# Altium schematic sheet BMS_project.SchDoc(BMS_project)
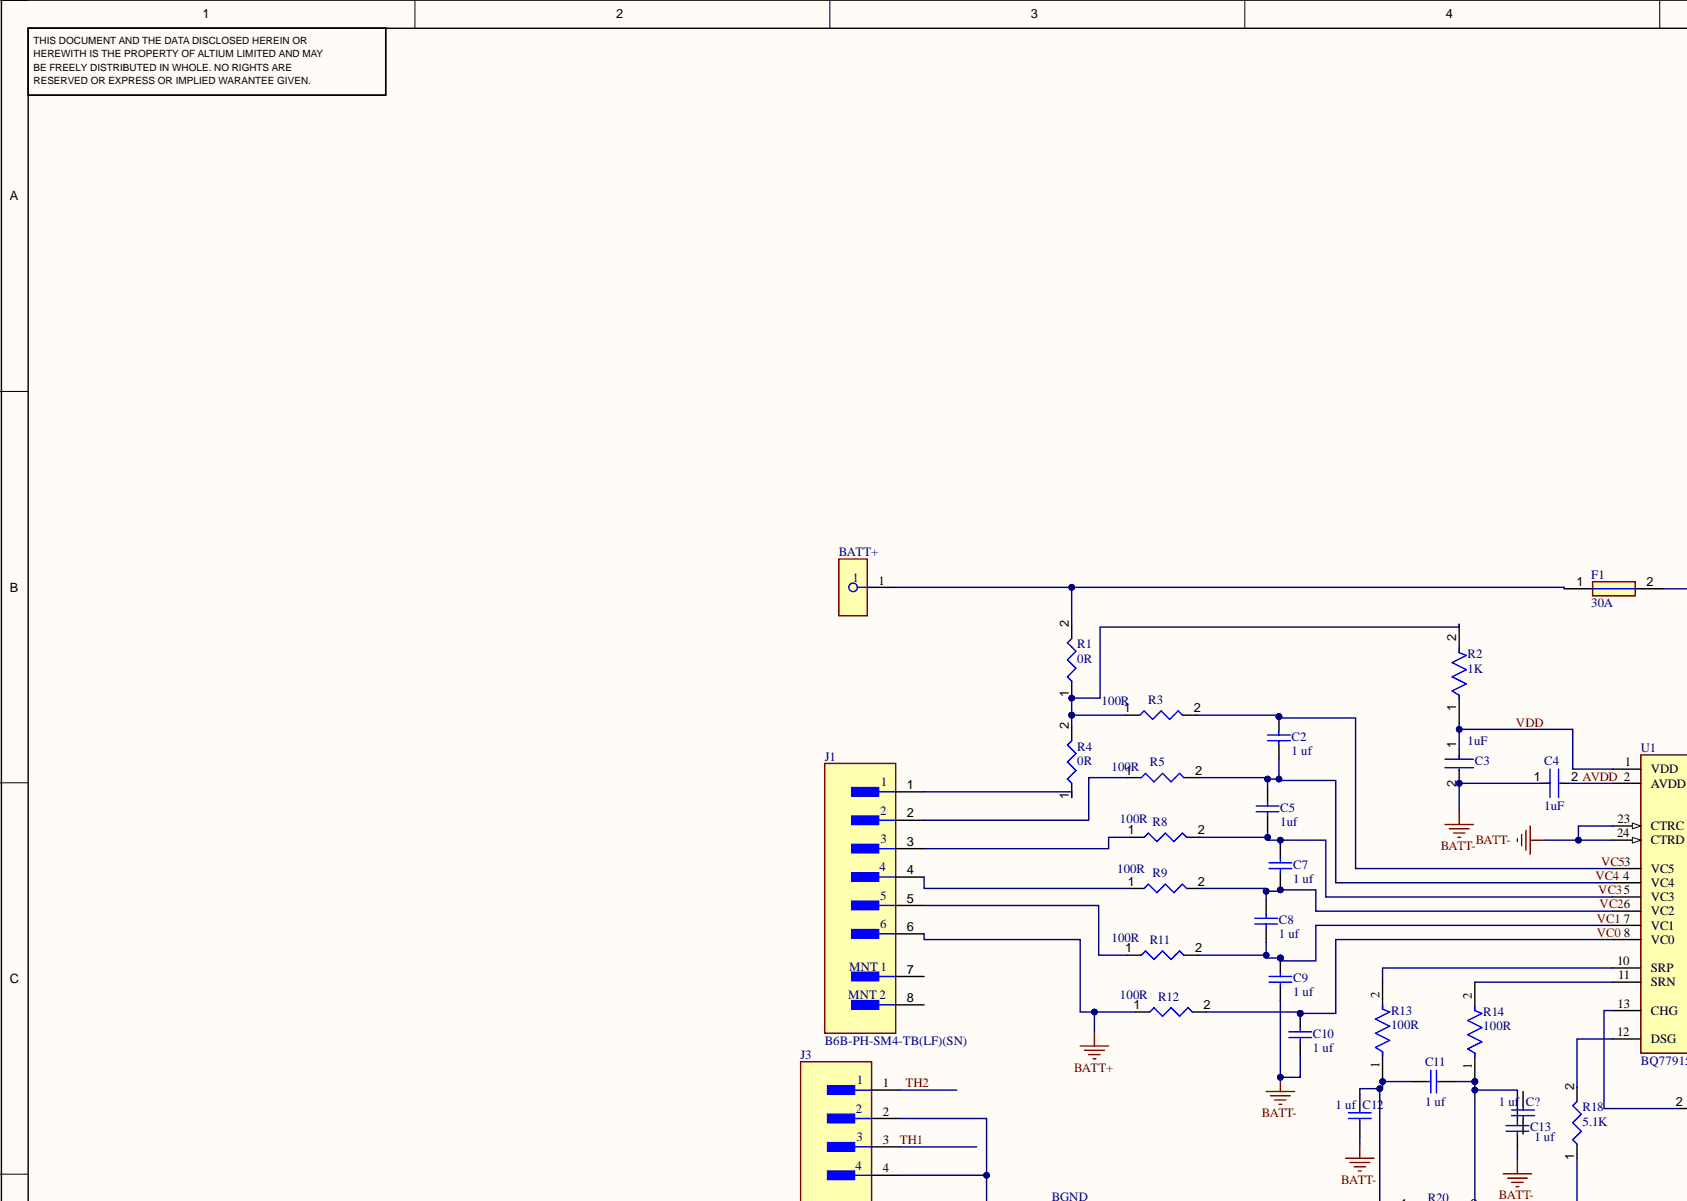
[diagram p0: BMS_project.SchDoc, top left]
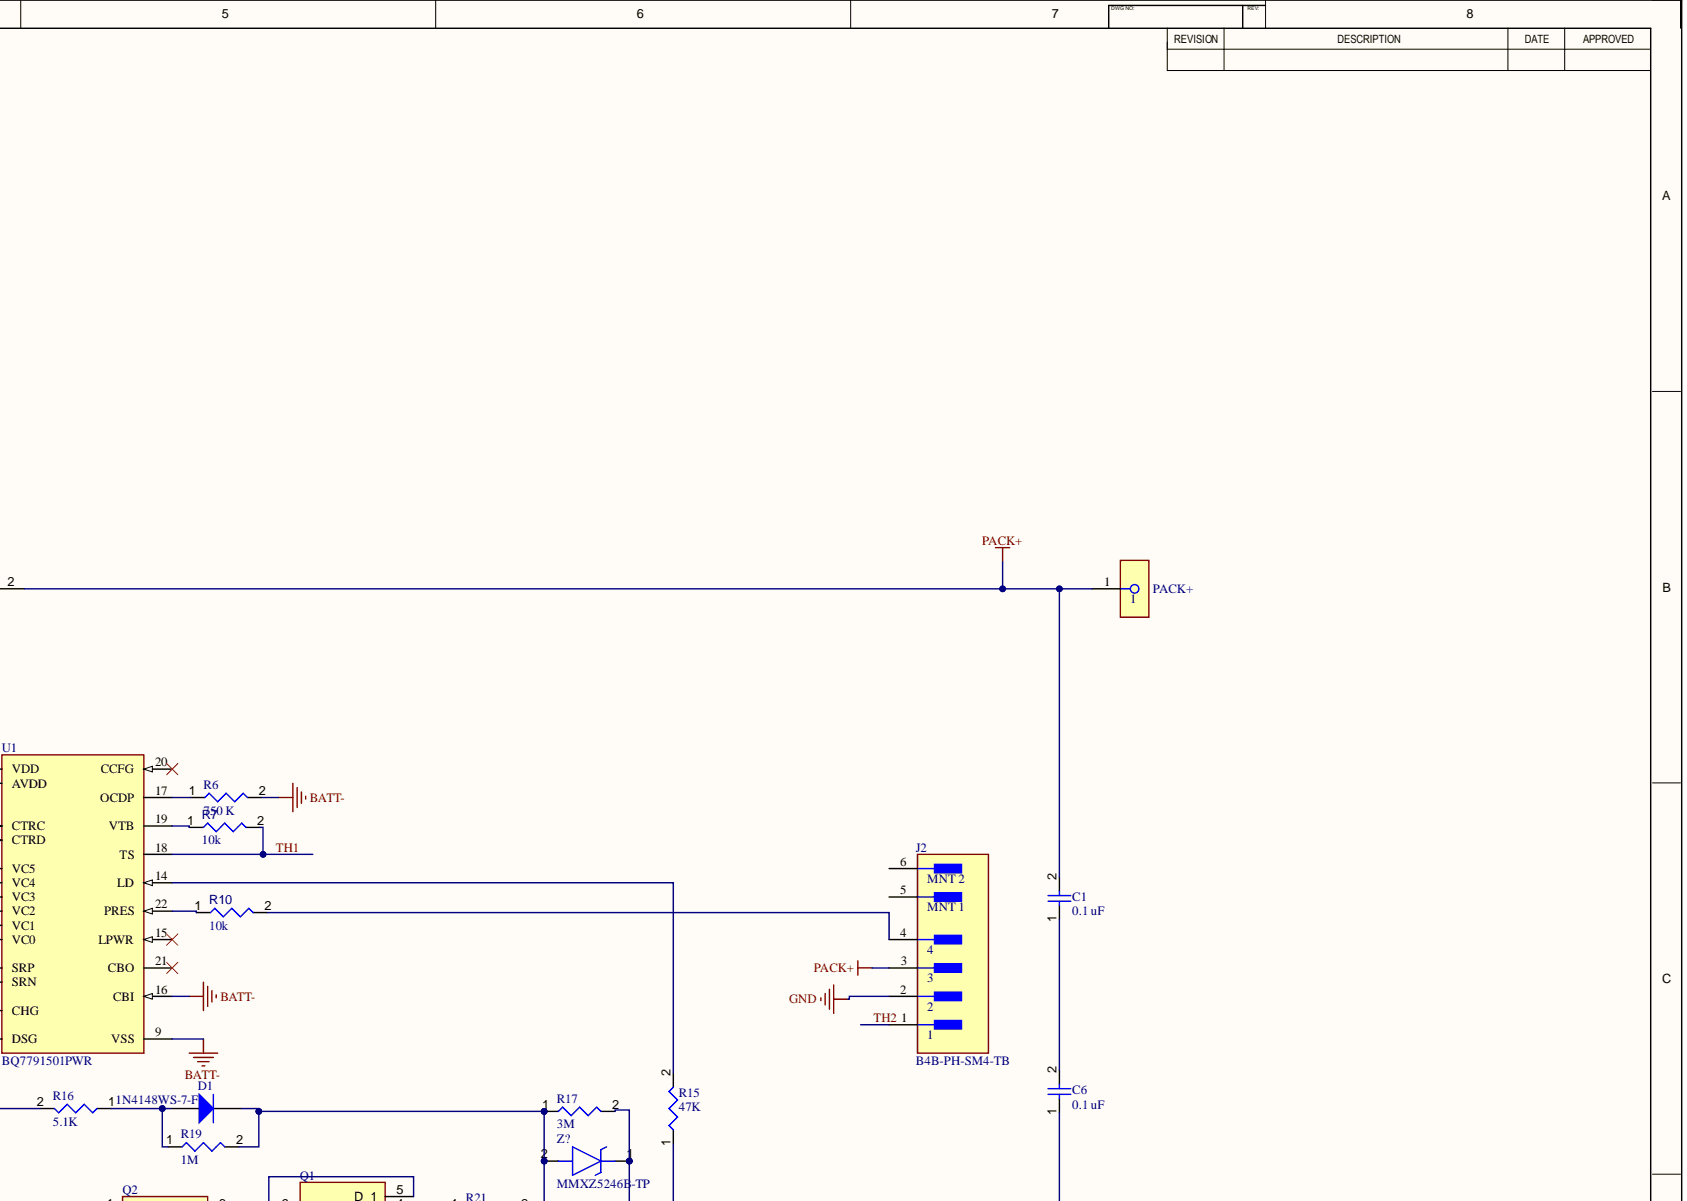
[diagram p1: BMS_project.SchDoc, top right]
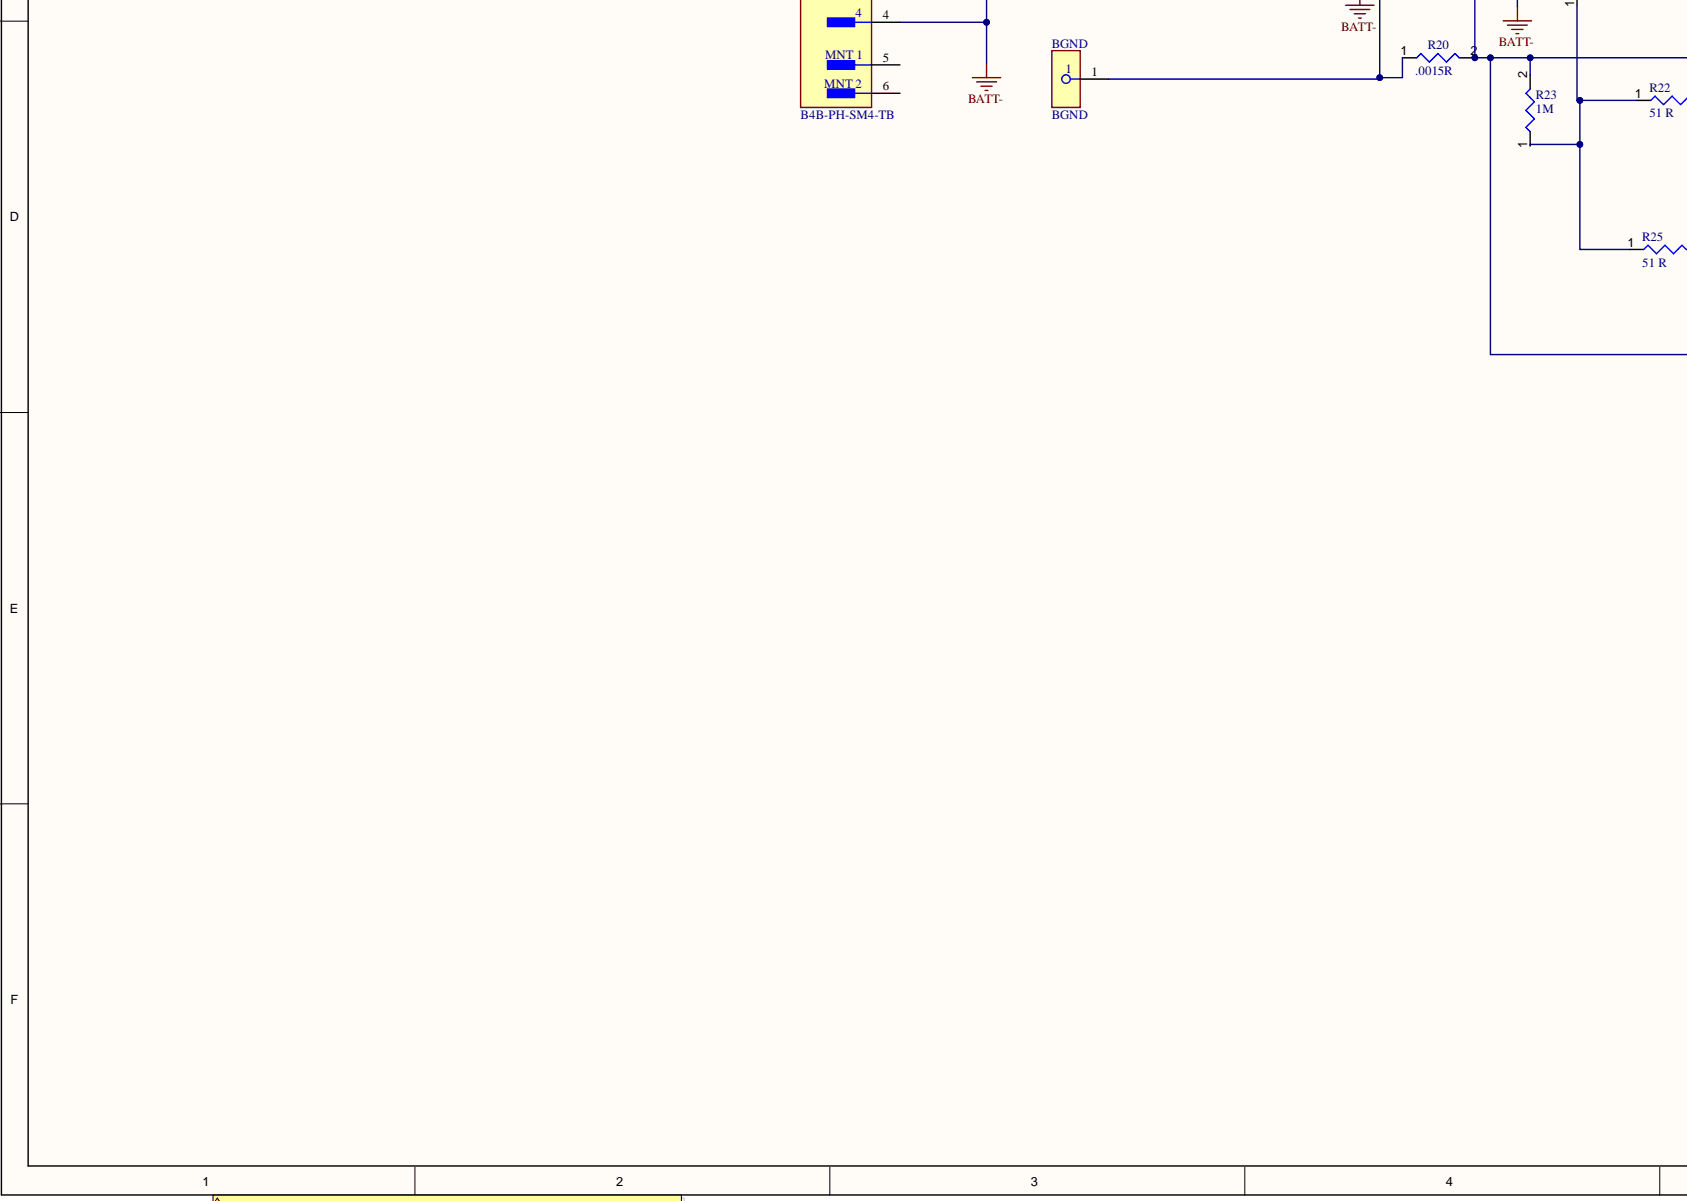
[diagram p2: BMS_project.SchDoc, bottom left]
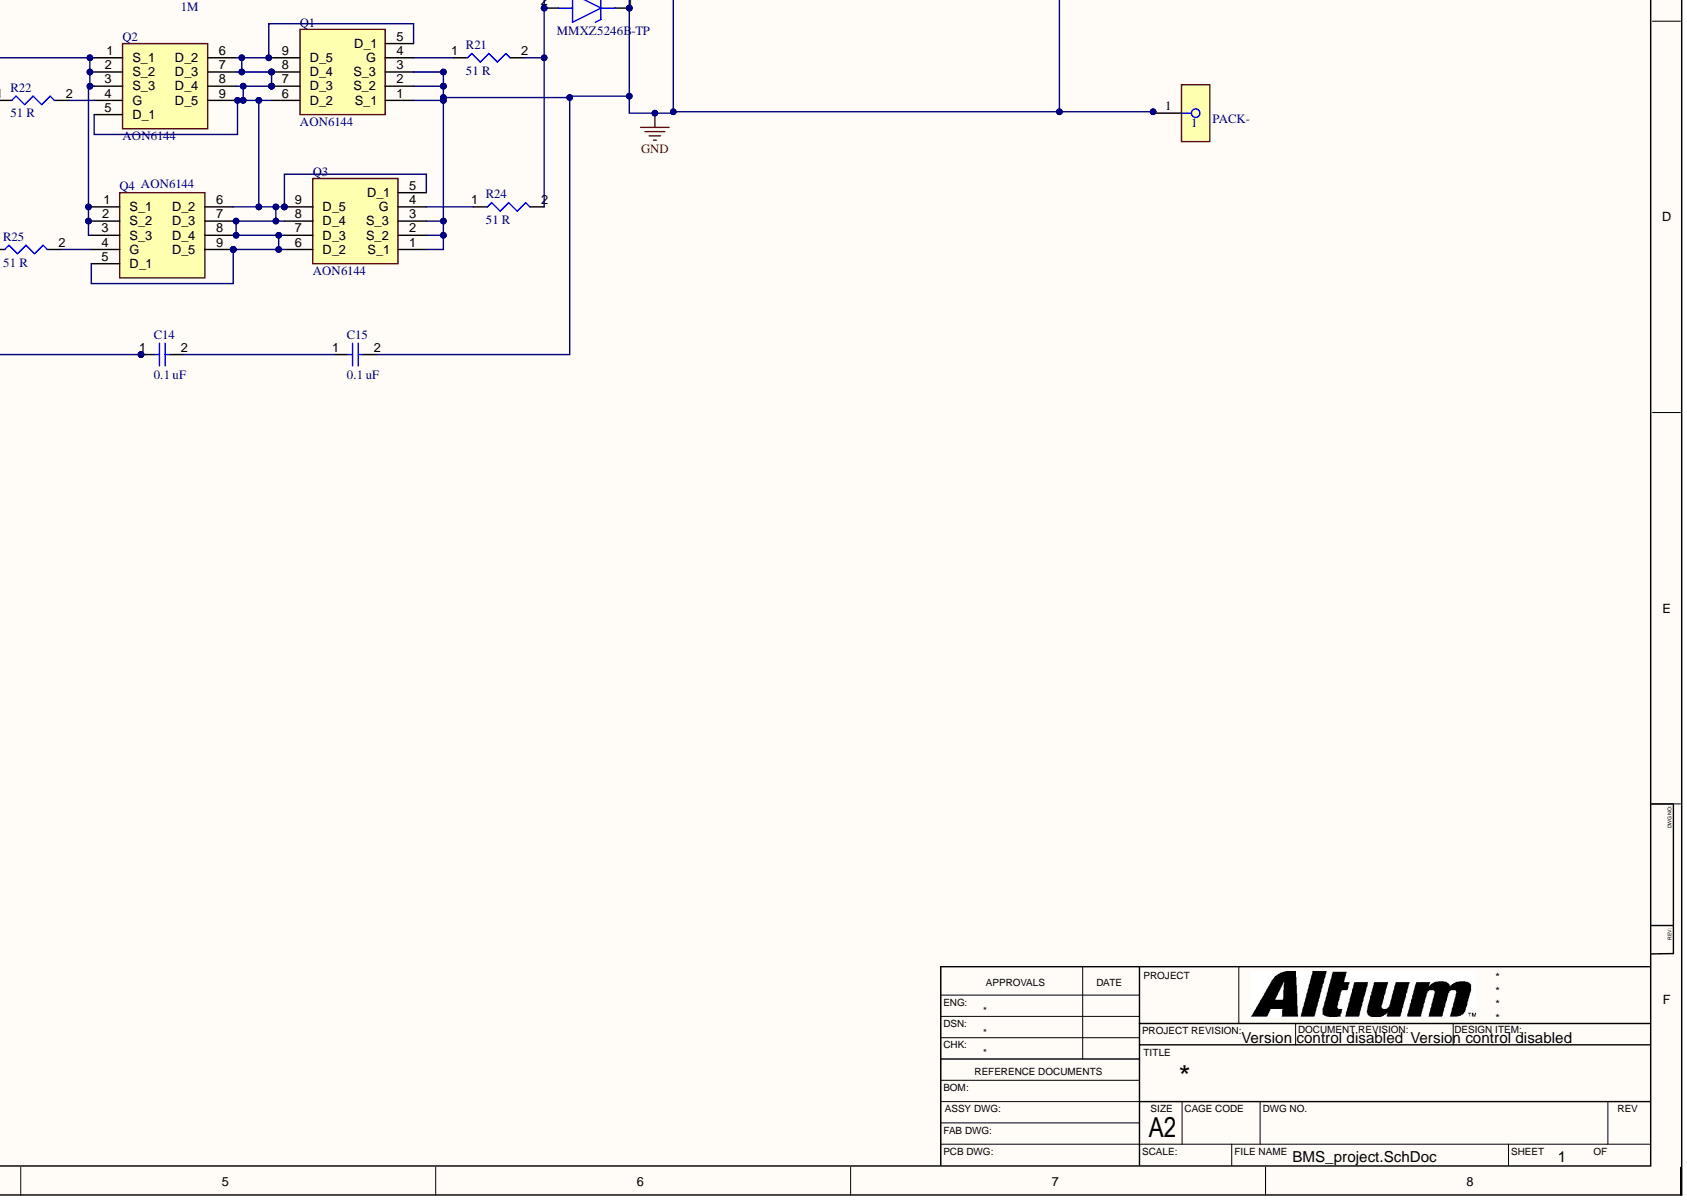
[diagram p3: BMS_project.SchDoc, bottom right]

## Parts
BATT+  BATT+
BGND  BGND
C1  0.1 uF
C2  1 uf
C3  1uF
C4  1uF
C5  1uf
C6  0.1 uF
C7  1 uf
C8  1 uf
C9  1 uf
C10  1 uf
C11  1 uf
C12  1 uf
C13  1 uf
C14  0.1 uF
C15  0.1 uF
C?  1 uf
D1  1N4148WS-7-F
F1  30A
J1  B6B-PH-SM4-TB(LF)(SN)
J2  B4B-PH-SM4-TB
J3  B4B-PH-SM4-TB
PACK+  (no value)
PACK-  LAMA2/0-14-QY  (value not drawn)
Q1  AON6144
Q2  AON6144
Q3  AON6144
Q4  AON6144
R1  0R
R2  1K
R3  100R
R4  0R
R5  100R
R6  750 K
R7  value 10k  (hidden comment: Resistor 10k +/-1% 0603 100 mW)
R8  100R
R9  100R
R10  value 10k  (hidden comment: Resistor 10k +/-1% 0603 100 mW)
R11  100R
R12  100R
R13  100R
R14  100R
R15  47K
R16  5.1K
R17  3M
R18  5.1K
R19  1M
R20  .0015R
R21  51 R
R22  51 R
R23  1M
R24  51 R
R25  51 R
U1  BQ7791501PWR
Z?  MMXZ5246B-TP

## Nets
BATT+: J1.6 R12.1
BATT-: C3.2 C4.1 C9.1 C10.1 C12.1 C13.1 J3.2 J3.4 R6.2 U1.9(VSS) U1.16(CBI) U1.23(CTRC) U1.24(CTRD)
GND: C6.1 C15.2 J2.2 PACK-.1 Q1.1(S_1) Q1.2(S_2) Q1.3(S_3) Q3.1(S_1) Q3.2(S_2) Q3.3(S_3) R15.1 R17.2 Z?.1
PACK+: C1.2 F1.2 J2.3 PACK+.1
AVDD: C4.2 U1.2(AVDD)
TH1: J3.3 R7.2 U1.18(TS)
TH2: J2.1 J3.1
VC0: C10.2 R12.2 U1.8(VC0)
VC1: C8.1 C9.2 R11.2 U1.7(VC1)
VC2: C7.1 C8.2 R9.2 U1.6(VC2)
VC3: C5.1 C7.2 R8.2 U1.5(VC3)
VC4: C2.1 C5.2 R5.2 U1.4(VC4)
VC5: C2.2 R3.2 U1.3(VC5)
VDD: C3.1 R2.1 U1.1(VDD)
NetBATT+_1: BATT+.1 F1.1 R1.2
NetBGND_1: BGND.1 C11.2 C12.2 R13.1 R20.1
NetC1_1: C1.1 C6.2
NetC11_1: C11.1 C13.2 C14.1 Q2.1(S_1) Q2.2(S_2) Q2.3(S_3) Q4.1(S_1) Q4.2(S_2) Q4.3(S_3) R14.1 R20.2 R23.2
NetC14_2: C14.2 C15.1
NetD1_1: D1.1 R16.1 R19.1
NetD1_2: D1.2 R17.1 R19.2 R21.2 R24.2 Z?.2
NetJ1_1: J1.1 R4.1
NetJ1_2: J1.2 R5.1
NetJ1_3: J1.3 R8.1
NetJ1_4: J1.4 R9.1
NetJ1_5: J1.5 R11.1
NetJ2_4: J2.4 R10.2
NetQ1_4: Q1.4(G) R21.1
NetQ1_5: Q1.5(D_1) Q1.6(D_2) Q1.7(D_3) Q1.8(D_4) Q1.9(D_5) Q2.5(D_1) Q2.6(D_2) Q2.7(D_3) Q2.8(D_4) Q2.9(D_5) Q3.5(D_1) Q3.6(D_2) Q3.7(D_3) Q3.8(D_4) Q3.9(D_5) Q4.5(D_1) Q4.6(D_2) Q4.7(D_3) Q4.8(D_4) Q4.9(D_5)
NetQ2_4: Q2.4(G) R22.2
NetQ3_4: Q3.4(G) R24.1
NetQ4_4: Q4.4(G) R25.2
NetR1_1: R1.1 R2.2 R3.1 R4.2
NetR6_1: R6.1 U1.17(OCDP)
NetR7_1: R7.1 U1.19(VTB)
NetR10_1: R10.1 U1.22(PRES)
NetR13_2: R13.2 U1.10(SRP)
NetR14_2: R14.2 U1.11(SRN)
NetR15_2: R15.2 U1.14(LD)
NetR16_2: R16.2 U1.13(CHG)
NetR18_1: R18.1 R22.1 R23.1 R25.1
NetR18_2: R18.2 U1.12(DSG)

Not connected: C? 1 2; J1 7 8; J2 5 6; J3 5 6; U1 15(LPWR) 20(CCFG) 21(CBO)

## Library data of the parts
BATT+ BGND PACK+ PACK- = CMP-00289-00092609-1: LAMA2/0-14-QY LAMA2/0-14-QY Aluminum Mechanical Lug, 1 Hole, 1/4", Sngle Barrel, 600V, 2/0-14AWG, 25Pcs; NexarPartId: 92609; Body Material: Aluminium; Body Plating: Tin; Gender: Female; Insulation: Non-Insulated; Number of Contacts: 1; Tab Thickness: 4.826mm; Wire Gauge (Max): 2AWG; Wire Gauge (Min): 14AWG; Wire/Cable Gauge: 0AWG; Case/Package: Aluminum; Component Kind: Standard; Contact Material: Aluminium; Contact Plating: Tin; Depth: 15.7mm; Length: 37.3mm; Library Name: Altium Content Vault; Material: Aluminium; Max Operating Temperature: 90°C; Mount: Screw; Orientation: Straight; Radiation Hardening: No; REACH SVHC: No SVHC; RoHS: Compliant; Termination: Screw; Type: Mechanical; Voltage Rating: 600V
C1 C6 C14 C15 = C0805C104K1RACTU: Ceramic Capacitor, Multilayer, Ceramic, 100V, 10% +Tol, 10% -Tol, X7R, 15% TC, 0.1uF, Surface Mount, 0805; Manufacturer: KEMET; Multilayer: Yes; Value: 100nF; Capacitor Type: Ceramic; Case/Package: 0805; Category: Ceramic Capacitors; Component Kind: Standard; ComponentLink1Description: https://datasheet.ciiva.com:443/pdfs/VipMasterIC/IC/KEME/KEME-S-A0008991654/KEME-S-A0008991654-1.pdf?src-supplier=IHS+Markit; ComponentLink1URL: https://datasheet.ciiva.com:443/pdfs/VipMasterIC/IC/KEME/KEME-S-A0008991654/KEME-S-A0008991654-1.pdf?src-supplier=IHS+Markit; ComponentLink2Description: https://datasheet.ciiva.com/7842/1776102-7842535.pdf?src-supplier=Element14; ComponentLink2URL: https://datasheet.ciiva.com/7842/1776102-7842535.pdf?src-supplier=Element14; ComponentLink3Description: https://datasheet.ciiva.com/18219/getdatasheetpartid-295573-18219253.pdf?src-supplier=Verical; ComponentLink3URL: https://datasheet.ciiva.com/18219/getdatasheetpartid-295573-18219253.pdf?src-supplier=Verical; Dielectric Material: Ceramic; Height: 1mm; JESD-609 Code: e3; Length: 2mm; Library Name: BMS_project.SchLib; Manufacturer Part Number: C0805C104K1RACTU; Max Operating Temperature: 125°C; Min Operating Temperature: -55°C; Mounting Technology: Surface Mount; Package Shape: Rectangular; Package Style: SMTMeter; Packaging: Tape and Reel; Pins: 2; Pricing: 1=0.18, 10=0.123, 50=0.123, 100=0.072, 1000=0.046, 10000=0.036 (USD); Rated DC Voltage (URdc): 100V; Reach SVHC Compliant: Yes; RoHS: Yes; RoHS Compliant: Yes; Stock: 206983; Supplier: Mouser; Supplier Part Number: 80-C0805C104K1R; Temperature Characteristics Code: X7R; Terminal Finish: Nickel; Termination: Wraparound; Tolerance  (fill in as +-): 10%; Tolerance (fill in as +-): 10%; Width: 1.25mm
C2 C5 C7-C13 C? = CMP-2007-03236-1: Manufacturer: Kemet; Part Number: C0805C105K5RACTU; Component Kind: Standard; Library Name: Altium Content Vault
C3 C4 = CMP-1036-04428-2: CAP CER 0.1UF 100V X7R 0805; Manufacturer: KEMET; Manufacturer Part Number: C0805C104K1RACTU; Capacitance: 0.10 μF; Material: Ceramic; Temperature Coefficient: 30ppm/°C; Tolerance: 0.1; Value: 0.10 μF; Automotive: No; Category: Cap; Component Kind: Standard; ComponentLink2Description: Datasheet; ComponentLink2URL: https://content.kemet.com/datasheets/KEM_C1003_C0G_SMD.pdf; Device Class L1: Passive Components; Device Class L2: Capacitors; Device Class L3: Ceramic Capacitors; Digikey Description: CAP CER 0.1UF 100V X7R 0805; Height: 0.88mm; Ipc Land Pattern Name: CAPC200125X78; Lead Free: Yes; Library Name: Altium Content Vault; Mouser Description: Capacitor 100 nF 100 VDC 0805; Octopart: https://octopart.com/c0805c104k1ractu-kemet-103953; Package: C0805C-DN; RoHS: TRUE; Supplier: Digikey; Supplier Part Number: 800-3746-1-ND; Temperature: -55°C to +125°C; Temperature Characteristic: X7R; Temperature Range High: +125°C; Temperature Range Low: -55°C; Voltage Rating: 100 V
D1 = CMP-004-00002-2: Fast Switching Diode, 75 V, 0.3 A, -65 to 150 degC, 2-Pin SOD323, RoHS, Tape and Reel; Manufacturer: Diodes Inc; Elements: 1; Forward Current: 300mA; Forward Voltage: 1.25V; Max Surge Current: 2A; Peak Reverse Current: 1uA; Recovery Time: 4ns; Case/Package: SOD; Component Kind: Standard; Datasheet URL: http://www.diodes.com/datasheets/ds30097.pdf; Datasheet Version: Rev. 25-2, 03/2016; Manufacturer URL: http://www.diodes.com/; Max Operating Temperature: 150°C; Min Operating Temperature: -65°C; Mounting Technology: SM; Package Description: 2-Pin Small Outline Diode, Body 1.7 x 1.3 mm; Package Quantity: 3000; Package Reference: SOD323-2; Packaging: TapeandReel; Pins: 2; RoHS Compliant: True; Library Name: Vijaya Bhaskar reddy Ambati
F1 = SF-3812F3000T-2: Fuse; Manufacturer_Name: Bourns; Component Kind: Standard; Datasheet Link: https://www.bourns.com/docs/product-datasheets/SF-3812F-T.pdf; Height: 3.3mm; Manufacturer_Part_Number: SF-3812F3000T-2; Mouser Part Number: 652-SF-3812F3000T-2; Mouser Price/Stock: https://www.mouser.co.uk/ProductDetail/Bourns/SF-3812F3000T-2?qs=vLWxofP3U2yO4nPWBdNZtQ%3D%3D
J1 = CMP-17439-000051-1: CONN HEADER SMD 6POS 2MM; Contact Resistance: 10mR; Current Rating: 2A; Gender: Male; Insulation Resistance: 1GR; Mated Stacking Heights: 8.6mm; Number of Contacts: 6; Voltage Rating: 100V; Color: Natural; Component Kind: Standard; Connector Type: Header; Contact Finish - Mating: TIN; Contact Material: Copper Alloy; Contact Mating Length: 0.13inch; Contact Plating: Tin; Contact Shape: Square; Contact Type: Male Pin; Fastening Type: Detent; Flammability Rating: UL94 V-0; Height: 9.4mm; Housing Color: Natural; Housing Material: Nylon; Insulation Color: Natural; Insulation Height: 0.26inch; Insulation Material: Nylon; Lead Free: Lead Free; Lead Pitch: 2mm; Length: 13.9mm; Library Name: Altium Content Vault; Max Operating Temperature: 85°C; Min Operating Temperature: -25°C; Mount: Surface Mount; Number of Poles: 6; Number of Positions: 6; Number of Rows: 1; Orientation: Straight; Packaging: Tape and Reel; Pitch: 2mm; REACH SVHC: No SVHC; Series: PH; Termination: Solder; Voltage Rating (AC): 100V; Width: 5.5mm; Working Voltage: 100V
J2 J3 = CMP-17439-000131-1: CONN HEADER SMD 4POS 2MM; Component Kind: Standard; Library Name: Altium Content Vault; Orientation: Vertical
Q1-Q4 = AON6144: MOSFET (N-Channel); Manufacturer_Name: Alpha & Omega Semiconductors; Arrow Part Number: AON6144; Arrow Price/Stock: https://www.arrow.com/en/products/aon6144/alpha-and-omega-semiconductor?region=nac; Component Kind: Standard; Datasheet Link: https://www.aosmd.com/sites/default/files/res/datasheets/AON6144.pdf; Height: 1.05mm; Manufacturer_Part_Number: AON6144
R1 R4 = RC0603FR-130RL: Metal Glaze/thick Film, 0.1W, 0ohm, Surface Mount, 0603; Manufacturer: Yageo; Category: Chip SMD Resistors; Component Kind: Standard; ComponentLink1Description: https://datasheet.octopart.com/RC0603FR-130RL-Yageo-datasheet-164759695.pdf?src-supplier=Future+Electronics; ComponentLink1URL: https://datasheet.octopart.com/RC0603FR-130RL-Yageo-datasheet-164759695.pdf?src-supplier=Future+Electronics; Library Name: BMS_project.SchLib; Manufacturer Part Number: RC0603FR-130RL; Pricing: 1=0.1, 10=0.01, 50=0.01, 100=0.005, 1000=0.004, 10000=0.003 (USD); RoHS: Yes; Stock: 52267; Supplier: Mouser; Supplier Part Number: 603-RC0603FR-130RL
R2 = CMP-1659-00009-5: RES 1K OHM 1% 1/10W 0603; Manufacturer: Vishay; Manufacturer Part Number: WSLF25121L500FEA; Max Power Dissipation: 100mW; Resistance: 1kR; Temperature Coefficient: 100ppm/°C; Voltage Rating: 50V; Case Code (Imperial): 0603; Case Code (Metric): 1608; Case/Package: 0603; Category: Chip SMD Resistors; Component Kind: Standard; Construction: Rectangular; Contact Plating: Tin; Depth: 0.8mm; Features: Moisture Resistant; Height: 0.45mm; Lead Free: Lead Free; Length: 1.6mm; Library Name: Altium Content Vault; Max Operating Temperature: 155°C; Military Standard: Not; Min Operating Temperature: -55°C; Number of Terminations: 2; Packaging: Tape and Reel; Power Rating: 100mW; Pricing: 1=0.78, 10=0.494, 50=0.494, 100=0.357, 1000=0.266, 10000=0.235 (USD); Radiation Hardening: No; REACH SVHC: No SVHC; Resistance Tolerance: 1%; Resistor Type: Thick Film; RoHS: No; RoHS Compliant: Yes; Series: RC; Size Code: 0603; Stock: 10903; Supplier: Mouser; Supplier Part Number: 71-WSLF25121L500FEA; Technology: THICK FILM; Termination: SMD/SMT; Tolerance: 1%
R3 R5 R8 R9 R11 R12 = RC1206FR-07100RL: Fixed Resistor, Metal Glaze/thick Film, 0.25W, 100ohm, 200V, 1% +/-Tol, 100ppm/Cel, Surface Mount, 1206; Manufacturer: Yageo; Additional Feature: LASER TRIMMABLE; Package Height: 0.55mm; Package Length: 3.1mm; Package Width: 1.6mm; Power: 250mW; Rated Temperature: 70°C; Temperature Coefficient: 100ppm/°C; Case/Package: 1206; Category: Chip SMD Resistors; Component Kind: Standard; ComponentLink1Description: https://datasheet.ciiva.com:443/pdfs/VipMasterIC/IC/YAGO/YAGO-S-A0008145938/YAGO-S-A0008145938-1.pdf?src-supplier=IHS+Markit; ComponentLink1URL: https://datasheet.ciiva.com:443/pdfs/VipMasterIC/IC/YAGO/YAGO-S-A0008145938/YAGO-S-A0008145938-1.pdf?src-supplier=IHS+Markit; ComponentLink2Description: https://datasheet.ciiva.com/11808/0900766b80c6630a-11808606.pdf?src-supplier=Rs; ComponentLink2URL: https://datasheet.ciiva.com/11808/0900766b80c6630a-11808606.pdf?src-supplier=Rs; ComponentLink3Description: https://datasheet.ciiva.com/46445/444357-46445702.pdf?src-supplier=Element14; ComponentLink3URL: https://datasheet.ciiva.com/46445/444357-46445702.pdf?src-supplier=Element14; ComponentLink4Description: https://datasheet.ciiva.com/7857/444357-7857241.pdf?src-supplier=Element14; ComponentLink4URL: https://datasheet.ciiva.com/7857/444357-7857241.pdf?src-supplier=Element14; ComponentLink5Description: https://datasheet.ciiva.com/7857/444357-7857242.pdf?src-supplier=Element14; ComponentLink5URL: https://datasheet.ciiva.com/7857/444357-7857242.pdf?src-supplier=Element14; Construction: Chip; JESD-609 Code: e3; Library Name: BMS_project.SchLib; Manufacturer Part Number: RC1206FR-07100RL; Max Operating Temperature: 155°C; Min Operating Temperature: -55°C; Mounting Technology: Surface Mount; Package Shape: Rectangular; Packaging: Tape and Reel; Pins: 2; Pricing: 1=0.1, 10=0.025, 50=0.025, 100=0.015, 1000=0.011, 10000=0.006 (USD); Reach SVHC Compliant: Yes; Resistor Type: FIXED RESISTOR; RoHS: Yes; RoHS Compliant: Yes; Stock: 537907; Supplier: Mouser; Supplier Part Number: 603-RC1206FR-07100RL; Technology: METAL GLAZE/THICK FILM; Terminal Finish: Nickel; Termination: Wraparound; Tolerance: 1%; Value: 100R; Working Voltage: 200V
R6 = RC0603FR-07750KL: Fixed Resistor, Metal Glaze/thick Film, 0.1W, 750000ohm, 75V, 1% +/-Tol, 100ppm/Cel, Surface Mount, 0603; Manufacturer: Yageo; Additional Feature: LASER TRIMMABLE; Package Height: 0.45mm; Package Length: 1.6mm; Package Width: 0.8mm; Power: 100mW; Rated Temperature: 70°C; Temperature Coefficient: 100ppm/°C; Value: 750kR; Case/Package: 0603; Category: Chip SMD Resistors; Component Kind: Standard; ComponentLink1Description: https://datasheet.ciiva.com:443/pdfs/VipMasterIC/IC/YAGO/YAGO-S-A0008145938/YAGO-S-A0008145938-1.pdf?src-supplier=IHS+Markit; ComponentLink1URL: https://datasheet.ciiva.com:443/pdfs/VipMasterIC/IC/YAGO/YAGO-S-A0008145938/YAGO-S-A0008145938-1.pdf?src-supplier=IHS+Markit; ComponentLink2Description: https://datasheet.ciiva.com/15189/getdatasheetpartid-326522-15189814.pdf?src-supplier=Verical; ComponentLink2URL: https://datasheet.ciiva.com/15189/getdatasheetpartid-326522-15189814.pdf?src-supplier=Verical; ComponentLink3Description: https://datasheet.ciiva.com/2454/pyu-r-int-thick-7-2454339.pdf?src-supplier=Digikey; ComponentLink3URL: https://datasheet.ciiva.com/2454/pyu-r-int-thick-7-2454339.pdf?src-supplier=Digikey; ComponentLink4Description: https://datasheet.ciiva.com/2454/pyu-r-int-thick-7-2454340.pdf?src-supplier=Digikey; ComponentLink4URL: https://datasheet.ciiva.com/2454/pyu-r-int-thick-7-2454340.pdf?src-supplier=Digikey; ComponentLink5Description: https://datasheet.ciiva.com/2454/pyu-r-int-thick-7-2454341.pdf?src-supplier=Digikey; ComponentLink5URL: https://datasheet.ciiva.com/2454/pyu-r-int-thick-7-2454341.pdf?src-supplier=Digikey; Construction: Chip; JESD-609 Code: e3; Library Name: BMS_project.SchLib; Manufacturer Part Number: RC0603FR-07750KL; Max Operating Temperature: 155°C; Min Operating Temperature: -55°C; Mounting Technology: Surface Mount; Package Shape: Rectangular; Packaging: Tape and Reel; Pins: 2; Pricing: 1=0.1, 10=0.012, 50=0.012, 100=0.007, 1000=0.005, 10000=0.002 (USD); Reach SVHC Compliant: Yes; Resistor Type: FIXED RESISTOR; RoHS: Yes; RoHS Compliant: Yes; Stock: 2195; Supplier: Mouser; Supplier Part Number: 603-RC0603FR-07750KL; Technology: METAL GLAZE/THICK FILM; Terminal Finish: Nickel; Termination: Wraparound; Tolerance: 1%; Working Voltage: 75V
R7 R10 = CMP-009-00017-5: Chip Resistor, 10 KOhm, +/- 1%, 01 W, -55 to 155 degC, 0603 (1608 Metric); Power: 100mW; Voltage Rating: 50V; Case/Package: 0603; Component Kind: Standard; Max Operating Temperature: 155°C; Min Operating Temperature: -55°C; Mounting Technology: SM; Pins: 2; RoHS Compliant: True; Tolerance: 1%; Library Name: Vijaya Bhaskar reddy Ambati
R13 R14 = CMP-2100-03663-2: NexarPartId: 55402879; Power Rating: 100mW; Resistance: 100Ω; Temperature Coefficient: 100ppm/°C; Tolerance: 1%; Voltage Rating: 50V; Case Code (Imperial): 0603; Case Code (Metric): 0603; Case/Package: 0603; Component Kind: Standard; Composition: Thick Film; Depth: 800µm; Height: 450µm; Lead Free: Lead Free; Length: 1.6mm; Library Name: Altium Content Vault; Max Operating Temperature: 155°C; Military Standard: Not; Min Operating Temperature: -55°C; Number of Pins: 2; Packaging: Tape & Reel; REACH SVHC: Unknown; RoHS: Compliant; Schedule B: 8533210045, 8533210045; Termination: SMD/SMT; Voltage Rating (DC): 50V; Width: 800µm
R15 = RC0603FR-0747KL: Fixed Resistor, Metal Glaze/thick Film, 0.1W, 47000ohm, 75V, 1% +/-Tol, 100ppm/Cel, Surface Mount, 0603; Manufacturer: Yageo; Additional Feature: LASER TRIMMABLE; Package Height: 0.45mm; Package Length: 1.6mm; Package Width: 0.8mm; Power: 100mW; Rated Temperature: 70°C; Temperature Coefficient: 100ppm/°C; Value: 47kR; Case/Package: 0603; Category: Chip SMD Resistors; Component Kind: Standard; ComponentLink1Description: https://datasheet.ciiva.com:443/pdfs/VipMasterIC/IC/YAGO/YAGO-S-A0008145938/YAGO-S-A0008145938-1.pdf?src-supplier=IHS+Markit; ComponentLink1URL: https://datasheet.ciiva.com:443/pdfs/VipMasterIC/IC/YAGO/YAGO-S-A0008145938/YAGO-S-A0008145938-1.pdf?src-supplier=IHS+Markit; Construction: Chip; JESD-609 Code: e3; Library Name: BMS_project.SchLib; Manufacturer Part Number: RC0603FR-0747KL; Max Operating Temperature: 155°C; Min Operating Temperature: -55°C; Mounting Technology: Surface Mount; Package Shape: Rectangular; Packaging: Tape and Reel; Pins: 2; Pricing: 1=0.1, 10=0.008, 50=0.008, 100=0.005, 1000=0.004, 10000=0.003 (USD); Reach SVHC Compliant: Yes; Resistor Type: FIXED RESISTOR; RoHS: Yes; RoHS Compliant: Yes; Stock: 381165; Supplier: Mouser; Supplier Part Number: 603-RC0603FR-0747KL; Technology: METAL GLAZE/THICK FILM; Terminal Finish: Nickel; Termination: Wraparound; Tolerance: 1%; Working Voltage: 75V
R16 R18 = ERJ-3EKF5101V: Fixed Resistor, Metal Glaze/thick Film, 0.1W, 5100ohm, 75V, 1% +/-Tol, 100ppm/Cel, Surface Mount, 0603; Manufacturer: Panasonic; Package Height: 0.45mm; Package Length: 1.6mm; Package Width: 0.8mm; Power: 100mW; Rated Temperature: 70°C; Reference Standard: AEC-Q200; Temperature Coefficient: 100ppm/°C; Value: 5.1kR; Case/Package: 0603; Category: Chip SMD Resistors; Component Kind: Standard; ComponentLink1Description: https://datasheet.ciiva.com:443/pdfs/VipMasterIC/IC/PANA/PANA-S-A0009889626/PANA-S-A0009889626-1.pdf?src-supplier=IHS+Markit; ComponentLink1URL: https://datasheet.ciiva.com:443/pdfs/VipMasterIC/IC/PANA/PANA-S-A0009889626/PANA-S-A0009889626-1.pdf?src-supplier=IHS+Markit; ComponentLink2Description: https://datasheet.ciiva.com/16785/70260748-16785996.pdf?src-supplier=Allied+Electronics; ComponentLink2URL: https://datasheet.ciiva.com/16785/70260748-16785996.pdf?src-supplier=Allied+Electronics; ComponentLink3Description: https://datasheet.ciiva.com/5860/426256-5860664.pdf?src-supplier=Farnell; ComponentLink3URL: https://datasheet.ciiva.com/5860/426256-5860664.pdf?src-supplier=Farnell; ComponentLink4Description: https://datasheet.ciiva.com/28547/1678782-28547194.pdf?src-supplier=Element14; ComponentLink4URL: https://datasheet.ciiva.com/28547/1678782-28547194.pdf?src-supplier=Element14; ComponentLink5Description: https://datasheet.ciiva.com/28686/1678782-28686921.pdf?src-supplier=Element14; ComponentLink5URL: https://datasheet.ciiva.com/28686/1678782-28686921.pdf?src-supplier=Element14; ComponentLink6Description: https://datasheet.ciiva.com/16440/aoa0000ce3-16440166.pdf?src-supplier=Future+Electronics; ComponentLink6URL: https://datasheet.ciiva.com/16440/aoa0000ce3-16440166.pdf?src-supplier=Future+Electronics; Construction: Rectangular; JESD-609 Code: e3; Library Name: BMS_project_1.SCHLIB; Manufacturer Part Number: ERJ-3EKF5101V; Max Operating Temperature: 155°C; Min Operating Temperature: -55°C; Mounting Technology: Surface Mount; Package Shape: Rectangular; Packaging: Tape and Reel; Pins: 2; Pricing: 1=0.1, 10=0.019, 50=0.019, 100=0.012, 1000=0.009, 10000=0.005 (USD); Reach SVHC Compliant: Yes; Resistor Type: FIXED RESISTOR; RoHS: Yes; RoHS Compliant: Yes; Stock: 14073; Supplier: Mouser; Supplier Part Number: 667-ERJ-3EKF5101V; Technology: METAL GLAZE/THICK FILM; Terminal Finish: Nickel; Termination: Wraparound; Tolerance: 1%; Working Voltage: 75V
R17 = RC0603FR-073ML: Fixed Resistor, Metal Glaze/thick Film, 0.1W, 3000000ohm, 75V, 1% +/-Tol, 100ppm/Cel, Surface Mount, 0603; Manufacturer: Yageo; Additional Feature: LASER TRIMMABLE; Package Height: 0.45mm; Package Length: 1.6mm; Package Width: 0.8mm; Power: 100mW; Rated Temperature: 70°C; Temperature Coefficient: 100ppm/°C; Value: 3MR; Case/Package: 0603; Category: Chip SMD Resistors; Component Kind: Standard; ComponentLink1Description: https://datasheet.ciiva.com:443/pdfs/VipMasterIC/IC/YAGO/YAGO-S-A0008145938/YAGO-S-A0008145938-1.pdf?src-supplier=IHS+Markit; ComponentLink1URL: https://datasheet.ciiva.com:443/pdfs/VipMasterIC/IC/YAGO/YAGO-S-A0008145938/YAGO-S-A0008145938-1.pdf?src-supplier=IHS+Markit; ComponentLink2Description: http://www.mouser.com/ds/2/447/RC0603-272842.pdf?src-supplier=Mouser; ComponentLink2URL: http://www.mouser.com/ds/2/447/RC0603-272842.pdf?src-supplier=Mouser; ComponentLink3Description: http://www.yageo.com/documents/recent/PYu-RC_Group_51_RoHS_L_7.pdf?src-supplier=Digikey; ComponentLink3URL: http://www.yageo.com/documents/recent/PYu-RC_Group_51_RoHS_L_7.pdf?src-supplier=Digikey; ComponentLink4Description: https://datasheet.octopart.com/RC0603FR-073ML-Yageo-datasheet-164759695.pdf?src-supplier=Future+Electronics; ComponentLink4URL: https://datasheet.octopart.com/RC0603FR-073ML-Yageo-datasheet-164759695.pdf?src-supplier=Future+Electronics; Construction: Chip; JESD-609 Code: e3; Library Name: BMS_project.SchLib; Manufacturer Part Number: RC0603FR-073ML; Max Operating Temperature: 155°C; Min Operating Temperature: -55°C; Mounting Technology: Surface Mount; Package Shape: Rectangular; Packaging: Tape and Reel; Pins: 2; Pricing: 1=0.1, 10=0.012, 50=0.012, 100=0.007, 1000=0.005, 10000=0.002 (USD); Reach SVHC Compliant: Yes; Resistor Type: FIXED RESISTOR; RoHS: Yes; RoHS Compliant: Yes; Stock: 589534; Supplier: Mouser; Supplier Part Number: 603-RC0603FR-073ML; Technology: METAL GLAZE/THICK FILM; Terminal Finish: Nickel; Termination: Wraparound; Tolerance: 1%; Working Voltage: 75V
R19 R23 = RC0603FR-071ML: Fixed Resistor, Metal Glaze/thick Film, 0.1W, 1000000ohm, 75V, 1% +/-Tol, 100ppm/Cel, Surface Mount, 0603; Manufacturer: Yageo; Additional Feature: LASER TRIMMABLE; Package Height: 0.45mm; Package Length: 1.6mm; Package Width: 0.8mm; Power: 100mW; Rated Temperature: 70°C; Temperature Coefficient: 100ppm/°C; Value: 1MR; Case/Package: 0603; Category: Chip SMD Resistors; Component Kind: Standard; ComponentLink1Description: https://datasheet.ciiva.com:443/pdfs/VipMasterIC/IC/YAGO/YAGO-S-A0008145938/YAGO-S-A0008145938-1.pdf?src-supplier=IHS+Markit; ComponentLink1URL: https://datasheet.ciiva.com:443/pdfs/VipMasterIC/IC/YAGO/YAGO-S-A0008145938/YAGO-S-A0008145938-1.pdf?src-supplier=IHS+Markit; ComponentLink2Description: https://datasheet.ciiva.com/11819/0900766b80f96399-11819295.pdf?src-supplier=Rs; ComponentLink2URL: https://datasheet.ciiva.com/11819/0900766b80f96399-11819295.pdf?src-supplier=Rs; ComponentLink3Description: https://datasheet.ciiva.com/7983/443971-7983614.pdf?src-supplier=Element14; ComponentLink3URL: https://datasheet.ciiva.com/7983/443971-7983614.pdf?src-supplier=Element14; ComponentLink4Description: https://datasheet.ciiva.com/12555/rc0603-272842-12555388.pdf?src-supplier=Mouser; ComponentLink4URL: https://datasheet.ciiva.com/12555/rc0603-272842-12555388.pdf?src-supplier=Mouser; Construction: Chip; JESD-609 Code: e3; Library Name: BMS_project.SchLib; Manufacturer Part Number: RC0603FR-071ML; Max Operating Temperature: 155°C; Min Operating Temperature: -55°C; Mounting Technology: Surface Mount; Package Shape: Rectangular; Packaging: Tape and Reel; Pins: 2; Pricing: 1=0.1, 10=0.012, 50=0.012, 100=0.007, 1000=0.005, 10000=0.003 (USD); Reach SVHC Compliant: Yes; Resistor Type: FIXED RESISTOR; RoHS: Yes; RoHS Compliant: Yes; Stock: 395356; Supplier: Mouser; Supplier Part Number: 603-RC0603FR-071ML; Technology: METAL GLAZE/THICK FILM; Terminal Finish: Nickel; Termination: Wraparound; Tolerance: 1%; Working Voltage: 75V
R20 = WSLF25121L500FEA: WSLF2512 .0015 1% Ea E3; Manufacturer: Vishay; Category: Chip SMD Resistors; Component Kind: Standard; Library Name: BMS_project.SchLib; Manufacturer Part Number: WSLF25121L500FEA; Pricing: 1=0.78, 10=0.494, 50=0.494, 100=0.357, 1000=0.266, 10000=0.235 (USD); RoHS: No; Stock: 10903; Supplier: Mouser; Supplier Part Number: 71-WSLF25121L500FEA
R21 R22 R24 R25 = RC0603FR-0751RL: Fixed Resistor, Metal Glaze/thick Film, 0.1W, 51ohm, 75V, 1% +/-Tol, 100ppm/Cel, Surface Mount, 0603; Manufacturer: Yageo; Additional Feature: LASER TRIMMABLE; Package Height: 0.45mm; Package Length: 1.6mm; Package Width: 0.8mm; Power: 100mW; Rated Temperature: 70°C; Temperature Coefficient: 100ppm/°C; Value: 51R; Case/Package: 0603; Category: Chip SMD Resistors; Component Kind: Standard; ComponentLink1Description: https://datasheet.ciiva.com:443/pdfs/VipMasterIC/IC/YAGO/YAGO-S-A0008145938/YAGO-S-A0008145938-1.pdf?src-supplier=IHS+Markit; ComponentLink1URL: https://datasheet.ciiva.com:443/pdfs/VipMasterIC/IC/YAGO/YAGO-S-A0008145938/YAGO-S-A0008145938-1.pdf?src-supplier=IHS+Markit; ComponentLink2Description: https://datasheet.ciiva.com/11808/0900766b80f96399-11808142.pdf?src-supplier=Rs; ComponentLink2URL: https://datasheet.ciiva.com/11808/0900766b80f96399-11808142.pdf?src-supplier=Rs; ComponentLink3Description: https://datasheet.ciiva.com/46395/443971-46395015.pdf?src-supplier=Element14; ComponentLink3URL: https://datasheet.ciiva.com/46395/443971-46395015.pdf?src-supplier=Element14; ComponentLink4Description: https://datasheet.ciiva.com/2454/pyu-r-int-thick-7-2454445.pdf?src-supplier=Digikey; ComponentLink4URL: https://datasheet.ciiva.com/2454/pyu-r-int-thick-7-2454445.pdf?src-supplier=Digikey; ComponentLink5Description: https://datasheet.ciiva.com/2454/pyu-r-int-thick-7-2454446.pdf?src-supplier=Digikey; ComponentLink5URL: https://datasheet.ciiva.com/2454/pyu-r-int-thick-7-2454446.pdf?src-supplier=Digikey; ComponentLink6Description: https://datasheet.ciiva.com/2454/pyu-r-int-thick-7-2454444.pdf?src-supplier=Digikey; ComponentLink6URL: https://datasheet.ciiva.com/2454/pyu-r-int-thick-7-2454444.pdf?src-supplier=Digikey; Construction: Chip; JESD-609 Code: e3; Library Name: BMS_project.SchLib; Manufacturer Part Number: RC0603FR-0751RL; Max Operating Temperature: 155°C; Min Operating Temperature: -55°C; Mounting Technology: Surface Mount; Package Shape: Rectangular; Packaging: Tape and Reel; Pins: 2; Pricing: 1=0.1, 10=0.01, 50=0.01, 100=0.007, 1000=0.005, 10000=0.002 (USD); Reach SVHC Compliant: Yes; Resistor Type: FIXED RESISTOR; RoHS: Yes; RoHS Compliant: Yes; Stock: 186797; Supplier: Mouser; Supplier Part Number: 603-RC0603FR-0751RL; Technology: METAL GLAZE/THICK FILM; Terminal Finish: Nickel; Termination: Wraparound; Tolerance: 1%; Working Voltage: 75V
U1 = CMP-04911-000025-1: IC POWER MANAGEMENT; Manufacturer: Texas Instruments; Category: Battery Management; Component Kind: Standard; ComponentLink1Description: https://www.ti.com:443/lit/ds/symlink/bq77915.pdf?src-supplier=IHS+Markit; ComponentLink1URL: https://www.ti.com:443/lit/ds/symlink/bq77915.pdf?src-supplier=IHS+Markit; ComponentLink2Description: http://www.ti.com/general/docs/suppproductinfo.tsp?distId=10&amp;gotoUrl=http%3A%2F%2Fwww.ti.com%2Flit%2Fgpn%2Fbq77915&src-supplier=Digikey; ComponentLink2URL: http://www.ti.com/general/docs/suppproductinfo.tsp?distId=10&amp;gotoUrl=http%3A%2F%2Fwww.ti.com%2Flit%2Fgpn%2Fbq77915&src-supplier=Digikey; Library Name: Altium Content Vault; Manufacturer Part Number: BQ7791501PWR; Pricing: 1=1.72, 10=1.17, 50=1.17, 100=1.02, 1000=0.846, 10000=0.738 (USD); RoHS: Yes; Stock: 8084; Supplier: Mouser; Supplier Part Number: 595-BQ7791501PWR
Z? = MMXZ5246B-TP: Zener Diode; Manufacturer_Name: MCC; Arrow Part Number: MMXZ5246B-TP; Arrow Price/Stock: https://www.arrow.com/en/products/mmxz5246b-tp/micro-commercial-components; Component Kind: Standard; Datasheet Link: https://mccsemi.com/pdf/Products/MMXZ5223B-MMXZ5259B(SOD-323).pdf; Height: 1.15mm; Manufacturer_Part_Number: MMXZ5246B-TP; Mouser Part Number: 833-MMXZ5246B-TP; Mouser Price/Stock: https://www.mouser.co.uk/ProductDetail/Micro-Commercial-Components-MCC/MMXZ5246B-TP?qs=ZNK0BnemlqGE%2F%2FlOHrNvMw%3D%3D
